# Altium schematic sheet Encoder.SchDoc
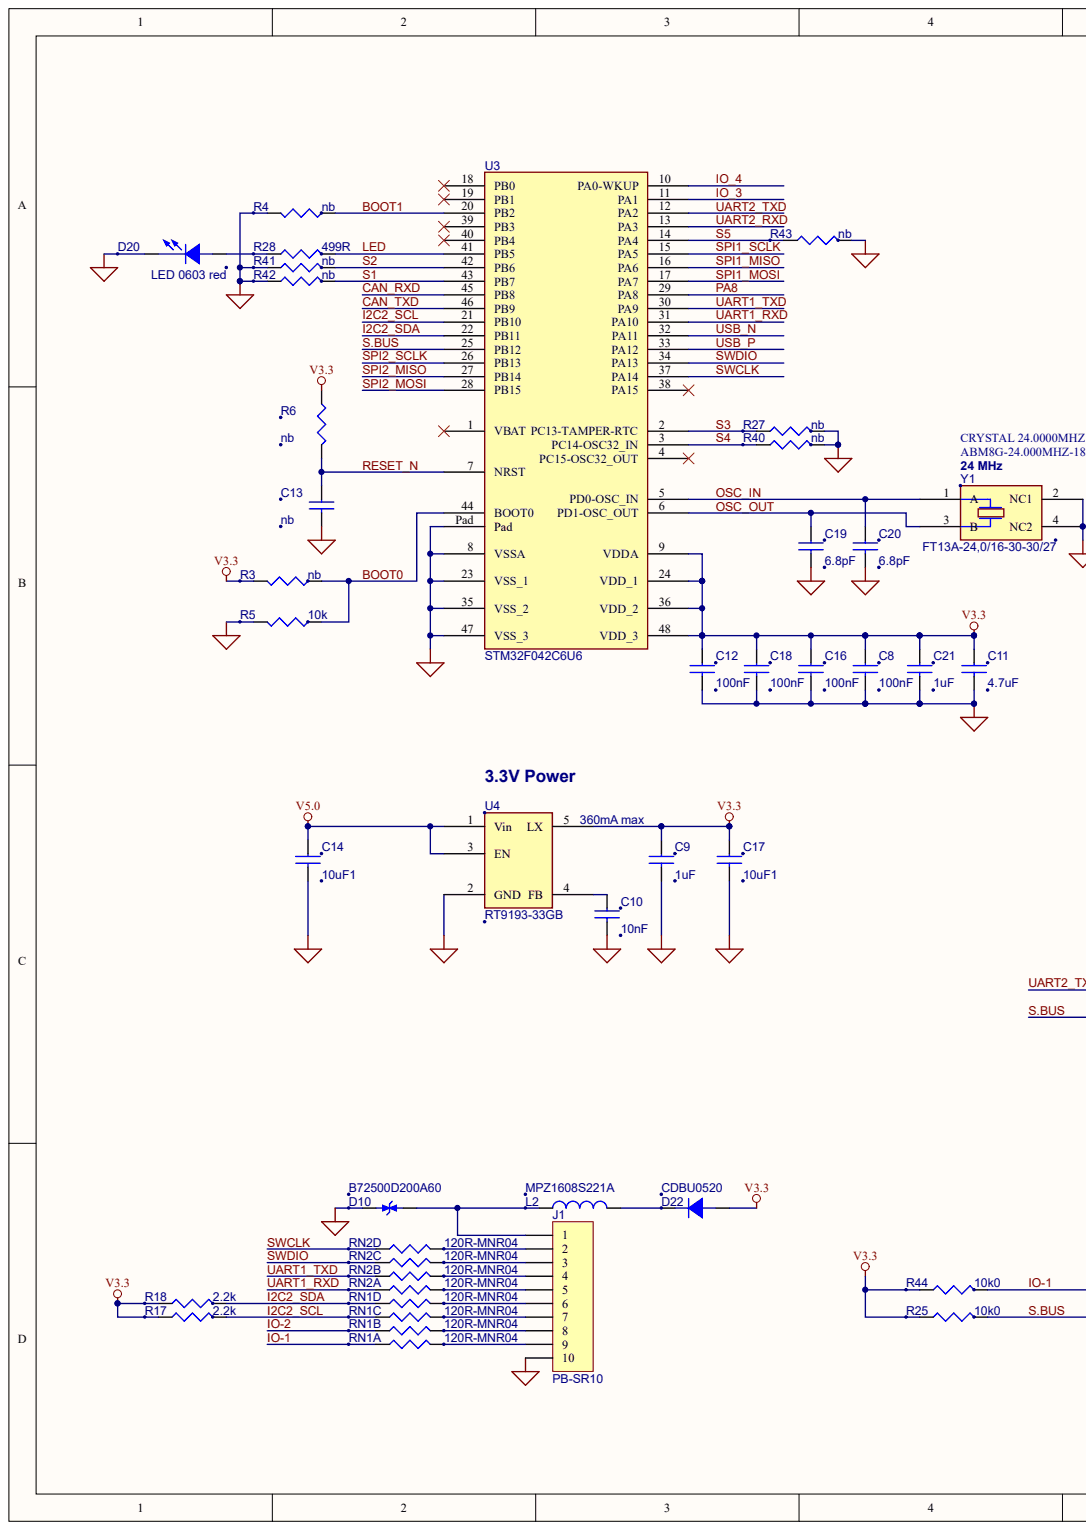
[diagram p0: Encoder.SchDoc, left]
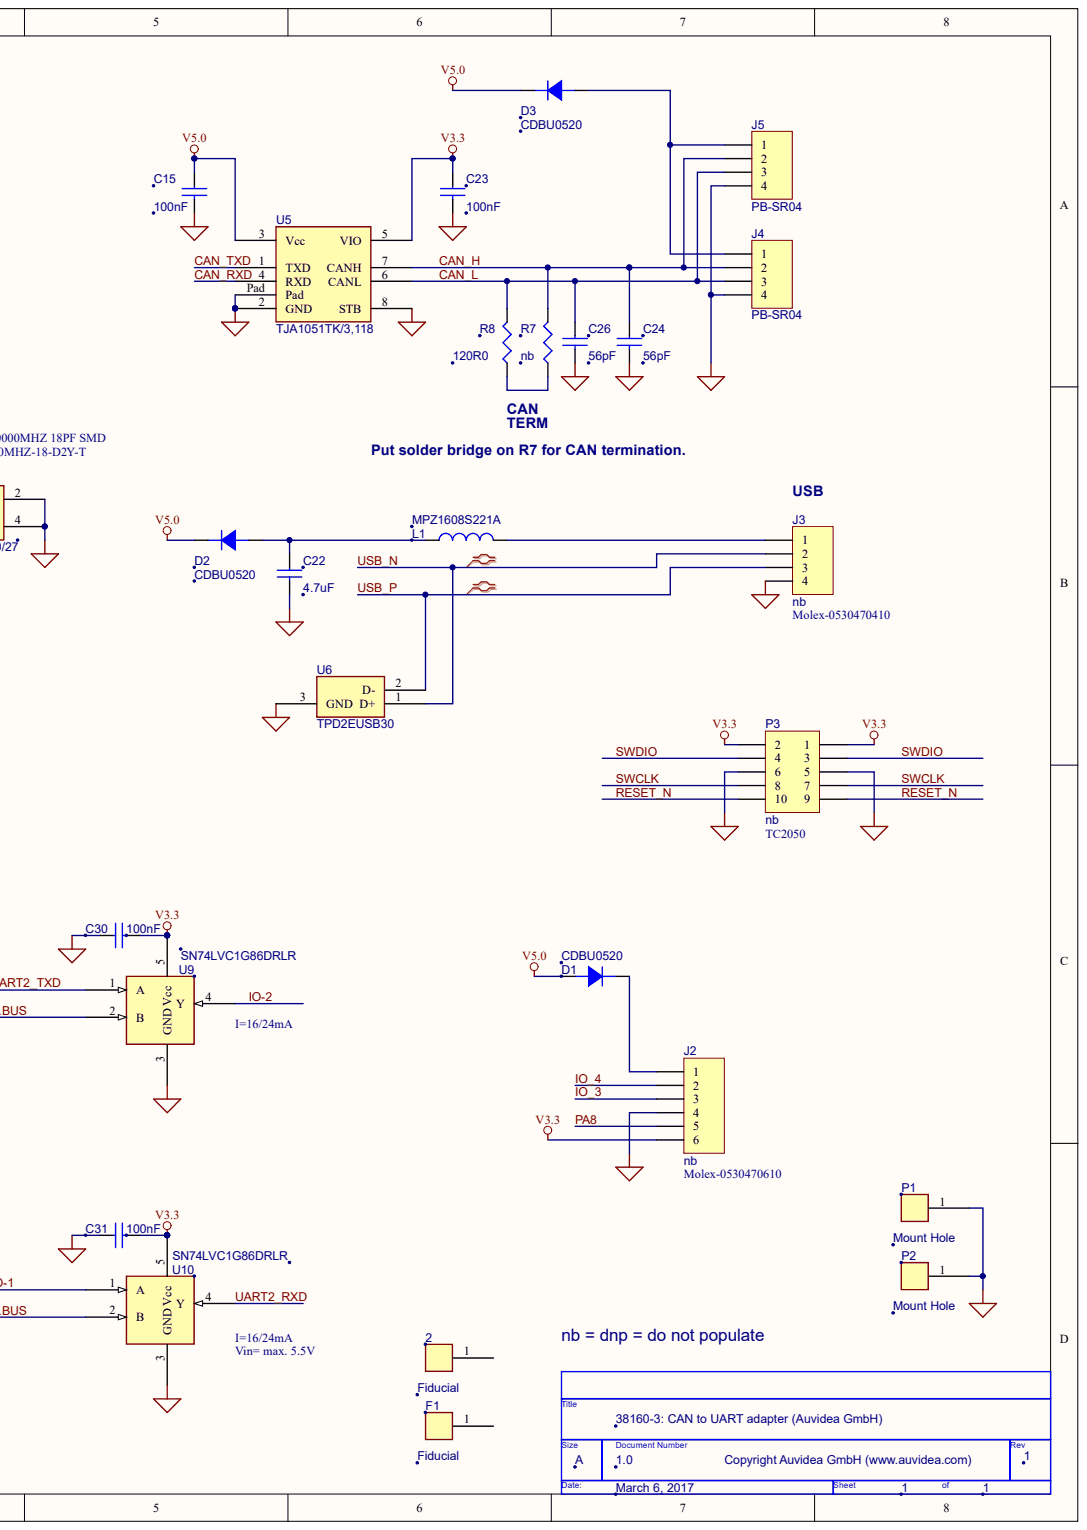
[diagram p1: Encoder.SchDoc, right]

## Parts
2  Fiducial
C8  100nF
C9  1uF
C10  10nF
C11  4.7uF
C12  100nF
C13  nb
C14  10uF1
C15  100nF
C16  100nF
C17  10uF1
C18  100nF
C19  6.8pF
C20  6.8pF
C21  1uF
C22  4.7uF
C23  100nF
C24  56pF
C26  56pF
C30  100nF
C31  100nF
D1  CDBU0520
D2  CDBU0520
D3  CDBU0520
D10  B72500D200A60
D20  LED 0603 red
D22  CDBU0520
F1  Fiducial
J1  PB-SR10
J2  nb
J3  value nb  (hidden comment: W9110-04PBTWRSR)
J4  PB-SR04
J5  PB-SR04
L1  MPZ1608S221A
L2  MPZ1608S221A
P1  Mount Hole
P2  Mount Hole
P3  nb
R3  nb
R4  nb
R5  10k
R6  nb
R7  nb
R8  120R0
R17  2.2k
R18  2.2k
R25  10k0
R27  nb
R28  499R
R40  nb
R41  nb
R42  nb
R43  nb
R44  10k0
RN1  120R-MNR04  (parts A,B,C,D)
RN2  120R-MNR04  (parts A,B,C,D)
U3  STM32F042C6U6
U4  RT9193-33GB
U5  TJA1051TK/3,118
U6  TPD2EUSB30
U9  SN74LVC1G86DRLR
U10  SN74LVC1G86DRLR
Y1  FT13A-24,0/16-30-30/27

## Nets
GND: C8.2 C9.2 C10.2 C11.2 C12.2 C13.2 C14.2 C15.2 C16.2 C17.2 C18.2 C19.2 C20.2 C21.2 C22.2 C23.2 C24.2 C26.2 C30.1 C31.1 D10.1 D20.C J1.10 J2.4 J3.4 J4.4 J5.4 P1.1 P2.1 P3.5 P3.6 R4.1 R5.1 R27.1 R40.1 R41.2 R42.2 R43.1 U3.8(VSSA) U3.23(VSS_1) U3.35(VSS_2) U3.47(VSS_3) U3.Pad U4.2(GND) U5.2(GND) U5.8(STB) U5.Pad U6.3 U9.3(GND) U10.3(GND) Y1.2(NC1) Y1.4(NC2)
V3.3: C8.1 C9.1 C11.1 C12.1 C16.1 C17.1 C18.1 C21.1 C23.1 C30.2 C31.2 D22.1 J2.6 P3.1 P3.2 R3.1 R6.2 R17.2 R18.2 R25.2 R44.2 U3.9(VDDA) U3.24(VDD_1) U3.36(VDD_2) U3.48(VDD_3) U4.5(LX) U5.5(VIO) U9.5(Vcc) U10.5(Vcc)
V5.0: C14.1 C15.1 D1.1 D2.2 D3.2 U4.1(Vin) U4.3(EN) U5.3(Vcc)
BOOT0: R3.2 R5.2 U3.44(BOOT0)
BOOT1: R4.2 U3.20(PB2)
CAN_H: C24.1 J4.2 J5.2 R7.1 U5.7(CANH)
CAN_L: C26.1 J4.3 J5.3 R8.1 U5.6(CANL)
CAN_RXD: U3.45(PB8) U5.4(RXD)
CAN_TXD: U3.46(PB9) U5.1(TXD)
I2C2_SCL: R17.1 RN1.3 U3.21(PB10)
I2C2_SDA: R18.1 RN1.4 U3.22(PB11)
IO-1: R44.1 RN1.1 U10.1(A)
IO-2: RN1.2 U9.4(Y)
IO_3: J2.3 U3.11(PA1)
IO_4: J2.2 U3.10(PA0-WKUP)
LED: R28.1 U3.41(PB5)
OSC_IN: C20.1 U3.5(PD0-OSC_IN) Y1.1(A)
OSC_OUT: C19.1 U3.6(PD1-OSC_OUT) Y1.3(B)
PA8: J2.5 U3.29(PA8)
RESET_N: C13.1 P3.9 P3.10 R6.1 U3.7(NRST)
S1: R42.1 U3.43(PB7)
S2: R41.1 U3.42(PB6)
S3: R27.2 U3.2(PC13-TAMPER-RTC)
S4: R40.2 U3.3(PC14-OSC32_IN)
S5: R43.2 U3.14(PA4)
S.BUS: R25.1 U3.25(PB12) U9.2(B) U10.2(B)
SPI1_MISO: U3.16(PA6)
SPI1_MOSI: U3.17(PA7)
SPI1_SCLK: U3.15(PA5)
SPI2_MISO: U3.27(PB14)
SPI2_MOSI: U3.28(PB15)
SPI2_SCLK: U3.26(PB13)
SWCLK: P3.7 P3.8 RN2.4 U3.37(PA14)
SWDIO: P3.3 P3.4 RN2.3 U3.34(PA13)
UART1_RXD: RN2.1 U3.31(PA10)
UART1_TXD: RN2.2 U3.30(PA9)
UART2_RXD: U3.13(PA3) U10.4(Y)
UART2_TXD: U3.12(PA2) U9.1(A)
USB_N: J3.2 U3.32(PA11) U6.1
USB_P: J3.3 U3.33(PA12) U6.2
NetC10_1: C10.1 U4.4(FB)
NetC22_1: C22.1 D2.1 L1.1
NetD1_2: D1.2 J2.1
NetD3_1: D3.1 J4.1 J5.1
NetD10_2: D10.2 J1.1 L2.1
NetD20_A: D20.A R28.2
NetD22_2: D22.2 L2.2
NetJ1_2: J1.2 RN2.5
NetJ1_3: J1.3 RN2.6
NetJ1_4: J1.4 RN2.7
NetJ1_5: J1.5 RN2.8
NetJ1_6: J1.6 RN1.5
NetJ1_7: J1.7 RN1.6
NetJ1_8: J1.8 RN1.7
NetJ1_9: J1.9 RN1.8
NetJ3_1: J3.1 L1.2
NetR7_2: R7.2 R8.2

Not connected: 2 1; F1 1; U3 1(VBAT) 4(PC15-OSC32_OUT) 18(PB0) 19(PB1) 38(PA15) 39(PB3) 40(PB4)

## Library data of the parts
D10 = (no symbol name): MDSPARTNO: D0080; Mfg Part No: PGB0010603MR; Mfg Part#: PGB1010603MR; Mfg: Littelfuse; PCAD: PGB0010603; PADS: PGB0010603; MDS Part#: D0080
J4 J5 = (no symbol name): PN: W9110-04PBTWRSR; VALUE: PB-SR06; LatestRevisionDate: 17-Jul-2002; LatestRevisionNote: Re-released for DXP Platform.; Publisher: Altium Limited
2 C8-C24 C26 C30 C31 D1-D3 D20 D22 F1 J1-J3 L1 L2 P1-P3 R3-R8 R17 R18 R25 R27 R28 R40-R44 RN1 RN2 U3-U6 U9 U10 Y1 = (no symbol name)
  J1 J2 P3: LatestRevisionDate: 17-Jul-2002; LatestRevisionNote: Re-released for DXP Platform.
  J3: LatestRevisionDate: 17-Jul-2002; LatestRevisionNote: Re-released for DXP Platform.; PN: W9110-04PBTWRSR
  J1-J3 P3: Publisher: Altium Limited
